# Altium schematic sheet ControlBoard_p2.SchDoc
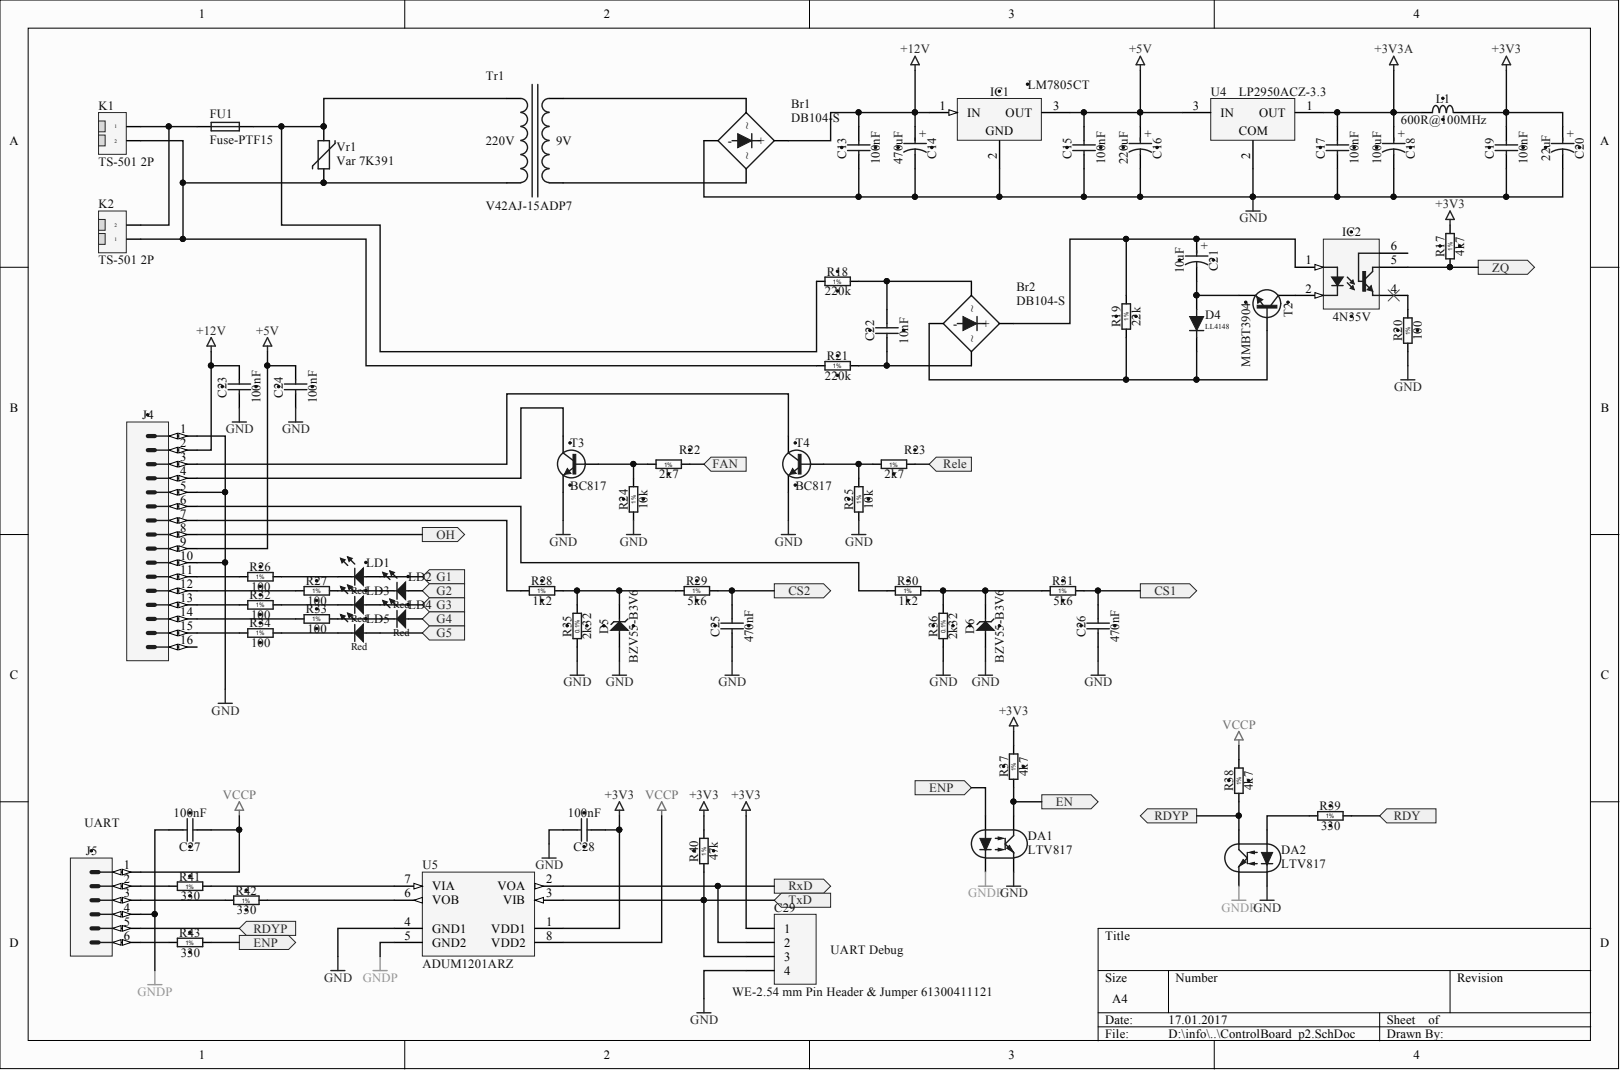
[diagram p0: ControlBoard_p2.SchDoc]

## Parts
Br1  DB104-S  [DB-S]
Br2  DB104-S  [DB-S]
C13  100nF  [CAPC2012X135N]
C14  470uF  [CAPPR500-1050X2200]
C15  100nF  [CAPC2012X135N]
C16  220uF  [CAPPR350-850X1300]
C17  100nF  [CAPC2012X135N]
C18  100uF  [CAPPR350-850X800]
C19  100nF  [CAPC2012X135N]
C20  22uF  [CAPPRB254-600X990]
C21  10uF  [CAPPR200-500X1100]
C22  10nF  [CAPR500-300X720X650]
C23  100nF  [CAPC2012X135N]
C24  100nF  [CAPC2012X135N]
C25  470nF  [CAPC2012X110N]
C26  470nF  [CAPC2012X110N]
C27  100nF  [CAPC2012X135N]
C28  100nF  [CAPC2012X135N]
C29  WE-2.54 mm Pin Header & Jumper 61300411121  [61300411121]
D4  LL4148  [SOD80/MLL34]
D5  BZV55-B3V6  [DIOMELF3515N]
D6  BZV55-B3V6  [DIOMELF3515N]
DA1  LTV817  [DIL4-300a]
DA2  LTV817  [DIL4-300a]
FU1  Fuse-PTF15  [FUSE-MCH]
IC1  LM7805CT  [TO-220-V]
IC2  4N35V  [DIP6-300]
J4  Header 16  [3M_N2516-6002RB]  (value not drawn)
J5  KK®  [MOLEX_22-27-2061]  (value not drawn)
K1  TS-501 2P  [TS-501 2P-2.5]
K2  TS-501 2P  [TS-501 2P-2.5]
L1  600R@100MHz  [INDC2012X110N]
LD1  Super Red  [LED_OSRAM_LSR976]  (value not drawn)
LD2  Super Red  [LED_OSRAM_LSR976]  (value not drawn)
LD3  Super Red  [LED_OSRAM_LSR976]  (value not drawn)
LD4  Super Red  [LED_OSRAM_LSR976]  (value not drawn)
LD5  Super Red  [LED_OSRAM_LSR976]  (value not drawn)
R17  4k7  [RESC2012X60N]
R18  220k  [RES1016-630X250]
R19  22k  [RESC2012X60N]
R20  100  [RESC2012X60N]
R21  220k  [RES1016-630X250]
R22  2k7  [RESC2012X60N]
R23  2k7  [RESC2012X60N]
R24  10k  [RESC2012X60N]
R25  10k  [RESC2012X60N]
R26  100  [RESC2012X60N]
R27  100  [RESC2012X60N]
R28  1k2  [RESC2012X60N]
R29  5k6  [RESC2012X60N]
R30  1k2  [RESC2012X60N]
R31  5k6  [RESC2012X60N]
R32  100  [RESC2012X60N]
R33  100  [RESC2012X60N]
R34  100  [RESC2012X60N]
R35  2k32  [RESC2012X60N]
R36  2k32  [RESC2012X60N]
R37  4k7  [RESC2012X60N]
R38  4k7  [RESC2012X60N]
R39  330  [RESC2012X60N]
R40  47k  [RESC2012X60N]
R41  330  [RESC2012X60N]
R42  330  [RESC2012X60N]
R43  330  [RESC2012X60N]
T2  MMBT3904  [SOT95P230X110-3N]
T3  BC817  [SOT95P230X110-3N]
T4  BC817  [SOT95P230X110-3N]
Tr1  V42AJ-15ADP7  [TR220-6W]
U4  LP2950ACZ-3.3  [LP3]
U5  ADUM1201ARZ  [ADI-R-8_N]
Vr1  Var 7K391  [VAR-7K-200]

## Ports
CS1, CS2, EN, ENP, FAN, G1, G2, G3, G4, G5, OH, RDY, RDYP, RELE, RXD, TXD, ZQ

## Nets
+3V3: C19.2 C20.1 C28.1 C29.1 L1.2 R17.2 R37.2 R40.2 U5.1(VDD1)
+3V3A: C17.2 C18.1 L1.1 U4.1
+5V: C15.2 C16.1 C24.2 IC1.3 J4.9 U4.3
+12V: Br1.1(+) C13.2 C14.1 C23.2 IC1.1 J4.2
GND: Br1.2(-) C13.1 C14.2 C15.1 C16.2 C17.1 C18.2 C19.1 C20.2 C23.1 C24.1 C25.1 C26.1 C28.2 C29.4 D5.2 D6.2 DA1.3 DA2.2 IC1.2 J4.1 J4.5 J4.10 R20.1 R24.1 R25.1 R35.1 R36.1 T3.2 T4.2 U4.2 U5.4(GND1)
GNDP: C27.2 DA1.2 DA2.3 J5.4 U5.5(GND2)
VCCP: C27.1 J5.1 R38.2 U5.8(VDD2)
CS1: C26.2 R31.2 ; port CS1
CS2: C25.2 R29.2 ; port CS2
EN: DA1.4 R37.1 ; port EN
ENP: DA1.1 R43.2 ; port ENP
FAN: R22.2 ; port FAN
G1: LD1.2 ; port G1
G2: LD2.2 ; port G2
G3: LD3.2 ; port G3
G4: LD4.2 ; port G4
G5: LD5.2 ; port G5
OH: J4.8 ; port OH
RDY: R39.2 ; port RDY
RDYP: DA2.4 J5.5 R38.1 ; port RDYP
ZQ: IC2.5 R17.1 ; port ZQ
NetBr1_3: Br1.3(~) Tr1.9
NetBr1_4: Br1.4(~) Tr1.7
NetBr2_1: Br2.1(+) C21.1 IC2.1 R19.2
NetBr2_2: Br2.2(-) D4.2 R19.1 T2.1
NetBr2_3: Br2.3(~) C22.2 R18.2
NetBr2_4: Br2.4(~) C22.1 R21.2
NetC21_2: C21.2 D4.1 T2.2
NetC29_2: C29.2 U5.2(VOA) ; port RXD
NetC29_3: C29.3 R40.1 U5.3(VIB) ; port TXD
NetD5_1: D5.1 R28.2 R29.1 R35.2
NetD6_1: D6.1 R30.2 R31.1 R36.2
NetDA2_1: DA2.1 R39.1
NetFU1_1: FU1.1 R18.1 Tr1.1 Vr1.1
NetFU1_2: FU1.2 K1.1 K2.2
NetIC2_2: IC2.2 T2.3
NetIC2_4: IC2.4 R20.2
NetJ4_3: J4.3 T4.3
NetJ4_4: J4.4 T3.3
NetJ4_6: J4.6 R30.1
NetJ4_7: J4.7 R28.1
NetJ4_11: J4.11 R26.1
NetJ4_12: J4.12 R27.1
NetJ4_13: J4.13 R32.1
NetJ4_14: J4.14 R33.1
NetJ4_15: J4.15 R34.1
NetJ5_2: J5.2 R41.1
NetJ5_3: J5.3 R42.1
NetJ5_6: J5.6 R43.1
NetK1_2: K1.2 K2.1 R21.1 Tr1.5 Vr1.2
NetLD1_1: LD1.1 R26.2
NetLD2_1: LD2.1 R27.2
NetLD3_1: LD3.1 R32.2
NetLD4_1: LD4.1 R33.2
NetLD5_1: LD5.1 R34.2
NetR22_1: R22.1 R24.2 T3.1
NetR23_1: R23.1 R25.2 T4.1
NetR23_2: R23.2 ; port RELE
NetR41_2: R41.2 U5.7(VIA)
NetR42_2: R42.2 U5.6(VOB)

Not connected: IC2 6; J4 16

## Library data of the parts
Br1 Br2 = DB104-S: SMD 1A 400V; Component Kind: Standard; Library Name: Diodes-Bridge.IntLib; Price: 0.23; Signal Integrity: D
C13 C15 C17 C19 C23 C24 C27 C28 = CC0805_100NF_50V_10%_X7R: Non-polarised capacitor; Manufacturer: GENERIC; Manufacturer1 Part Number: B37941K5104K62; Part Description: SMD Multilayer Chip Ceramic Capacitor; Database Table Name: Capacitors SMD; TC: X7R; Tolerance: ±10%; Voltage: 50V; Case: 0805; Component Kind: Standard; Component Type: Standard; CreateDate: 03.12.2007; HelpURL: \\cern.ch\dfs\Applications\Altium\Datasheets\CC0805_X7R_EPCOS.pdf; LatestRevisionDate: 03.12.2007; Library Name: Capacitors.DbLib; Manufacturer1 ComponentHeight: 1.35mm; Manufacturer1 Example: EPCOS; Manufacturer Part Number: CC0805_100NF_50V_10%_X7R; Mounted: Yes; Part Number: CC0805_100NF_50V_10%_X7R; Pin Count: 2; SMD: Yes; Socket: No; Status: Preferred; Table: Capacitors SMD; Value: 100nF; Author: CERN DEM JLC
C14 = CAER_470UF_25V_20%_500-1050X2200_LESR0R062: Polarised capacitor; Manufacturer: VISHAY BCCOMPONENTS; Manufacturer Part Number: MAL213666471E3 Series 136RVI; Part Description: Low ESR 0R062 Aluminum Electrolytic Capacitor; Database Table Name: Capacitors THD; Tolerance: ±20%; Voltage: 25V; Case: LS5 D10.5 x H22; ComponentHeight: 22mm; Component Kind: Standard; Component Type: Standard; CreateDate: 03.07.2008; HelpURL: \\cern.ch\dfs\Applications\Altium\Datasheets\CAER-LESR_VISHAY_136RVI.pdf; LatestRevisionDate: 16.03.2009; Library Name: Capacitors.DbLib; Mounted: Yes; Part Number: CAER_470UF_25V_20%_500-1050X2200_LESR0R062; Pin Count: 2; SMD: No; Socket: No; Status: Preferred; Table: Capacitors THD; Value: 470uF; Author: CERN DEM JLC
C16 = CAER_220UF_25V_20%_RUBYCON_25YXF220M8X11.5: Polarised capacitor; Part Description: Radial Miniature, Aluminum Electrolytic Capacitor, (YXF Series); Database Table Name: Capacitors THD; Tolerance: ±20%; Voltage: 25V; Case: LS3.5 D8.5 x H13; ComponentHeight: 13mm; Component Kind: Standard; Component Type: Standard; CreateDate: 20.08.2009; HelpURL: \\cern.ch\dfs\Applications\Altium\Datasheets\CAER_RUBYCON_YXF.pdf; LatestRevisionDate: 17.09.2009; Library Name: Capacitors.DbLib; Manufacturer: RUBYCON; Manufacturer Part Number: 25YXF220M8X11.5; Mounted: Yes; Part Number: CAER_220UF_25V_20%_RUBYCON_25YXF220M8X11.5; Pin Count: 2; SMD: No; Socket: No; Status: None; Table: Capacitors THD; Value: 220uF; Author: CERN DEM JLC
C18 = CAER_100UF_25V_20%_RUBYCON_25ML100M8X7: Polarised capacitor; Part Description: Aluminum Electrolytic Capacitor, (ML Series); Database Table Name: Capacitors THD; Tolerance: ±20%; Voltage: 25V; Case: LS3.5 D8.5 x H8; ComponentHeight: 8mm; Component Kind: Standard; Component Type: Standard; CreateDate: 17.11.2008; HelpURL: \\cern.ch\dfs\Applications\Altium\Datasheets\CAER_RUBYCON_25ML100M8X7.pdf; LatestRevisionDate: 08.05.2013; Library Name: Capacitors.DbLib; Manufacturer: RUBYCON; Manufacturer Part Number: 25ML100M8X7; Mounted: Yes; Part Number: CAER_100UF_25V_20%_RUBYCON_25ML100M8X7; Pin Count: 2; SMD: No; Socket: No; Status: None; Table: Capacitors THD; Value: 100uF; Author: CERN DEM JMW
C20 = CTEB_22UF_16V_10%_254-600X990: Polarised capacitor; Manufacturer: KEMET; Manufacturer Part Number: T350F226K016AT; Part Description: Solid Tantalum Resin Dipped Capacitor; Database Table Name: Capacitors THD; Tolerance: ±10%; Voltage: 16V; Case: LS2.54 D6 x H9.9; ComponentHeight: 9.9mm; Component Kind: Standard; Component Type: Standard; CreateDate: 16.12.2009; HelpURL: \\cern.ch\dfs\Applications\Altium\Datasheets\CTEB_KEMET_T350.pdf; LatestRevisionDate: 16.12.2009; Library Name: Capacitors.DbLib; Mounted: Yes; Part Number: CTEB_22UF_16V_10%_254-600X990; Pin Count: 2; SMD: No; Socket: No; Status: None; Table: Capacitors THD; Value: 22uF; Author: CERN DEM JLC
C21 = CAER_10UF_63V_20%_PANASONIC_ECA1JM100: Polarised capacitor; Part Description: Aluminum Electrolytic Capacitor (Series: M Type: A); Database Table Name: Capacitors THD; Tolerance: ±20%; Voltage: 63V; Case: LS2 D5 x H11; ComponentHeight: 11mm; Component Kind: Standard; Component Type: Standard; CreateDate: 04.03.2009; HelpURL: \\cern.ch\dfs\Applications\Altium\Datasheets\CAER_PANASONIC_M.pdf; LatestRevisionDate: 04.03.2009; Library Name: Capacitors.DbLib; Manufacturer: PANASONIC; Manufacturer Part Number: ECA1JM100; Mounted: Yes; Part Number: CAER_10UF_63V_20%_PANASONIC_ECA1JM100; Pin Count: 2; SMD: No; Socket: No; Status: None; Table: Capacitors THD; Value: 10uF; Author: CERN DEM JLC
C22 = CPER_10NF_400V_10%_500-300X720X650: Non-polarised capacitor; Manufacturer: EPCOS; Manufacturer Part Number: B32529C6103K000; Part Description: Metallized Polyester Film Capacitor (MKT); Database Table Name: Capacitors THD; Tolerance: ±10%; Voltage: 400VDC/200VAC; Case: LS5 W3 x L7.2 x H6.5; ComponentHeight: 6.5mm; Component Kind: Standard; Component Type: Standard; CreateDate: 23.03.2009; HelpURL: \\cern.ch\dfs\Applications\Altium\Datasheets\CPER_EPCOS_B32529.pdf; LatestRevisionDate: 23.03.2009; Library Name: Capacitors.DbLib; Mounted: Yes; Part Number: CPER_10NF_400V_10%_500-300X720X650; Pin Count: 2; SMD: No; Socket: No; Status: None; Table: Capacitors THD; Value: 10nF; Author: CERN DEM JLC
C25 C26 = CC0805_470NF_50V_10%_X7R: Non-polarised capacitor; Manufacturer: GENERIC; Manufacturer1 Part Number: C0805C474K5RACTU; Part Description: SMD Multilayer Chip Ceramic Capacitor; Database Table Name: Capacitors SMD; TC: X7R; Tolerance: ±10%; Voltage: 50V; Case: 0805; Component Kind: Standard; Component Type: Standard; CreateDate: 04.12.2013; HelpURL: \\cern.ch\dfs\Applications\Altium\Datasheets\CC0805_X7R_KEMET.pdf; LatestRevisionDate: 04.12.2013; Library Name: Capacitors.DbLib; Manufacturer1 ComponentHeight: 1.1mm; Manufacturer1 Example: KEMET; Manufacturer Part Number: CC0805_470NF_50V_10%_X7R; Mounted: Yes; Part Number: CC0805_470NF_50V_10%_X7R; Pin Count: 2; SMD: Yes; Socket: No; Status: Preferred; Table: Capacitors SMD; Value: 470nF; Author: CERN DEM JLC
C29 = WE-2.54 mm Pin Header & Jumper 61300411121: WR-PHD 2.54mm Pin Header; Manufacturer: WЁ№rth Elektronik eiSos GmbH; ComponentLink1 Description: Manufacturer; ComponentLink2 Description: Datasheet; NoPins: 04; Component Kind: Standard; ComponentLink1URL: http://www.we-online.com; ComponentLink2URL: http://katalog.we-online.de/em/datasheet/6130xx11121.pdf; Created Date: 22 March 2013; Library Name: WE_WR-PHD_Pin Header_2.54mm_rev15c.IntLib; partNo: 61300411121; Created by: Karrer Zheng
D4 = LL4148: 75V 0.3A SOD80/MLL34; Component Kind: Standard; Library Name: Diodes.IntLib; Price: 0.006; Signal Integrity: D; Simulation: 1N4148
D5 D6 = BZV55-B3V6: Zener Diode; Manufacturer: NXP SEMICONDUCTORS; Part Description: Voltage Regulator Diode; Database Table Name: Diodes; Power: 500mW; Voltage: 3V6; Case: SOD-80C; ComponentHeight: 1.6mm; Component Kind: Standard; Component Type: Standard; CreateDate: 06.05.2008; Device: BZV55-B3V6; HelpURL: \\cern.ch\dfs\Applications\Altium\Datasheets\BZV55.pdf; LatestRevisionDate: 06.05.2008; Library Name: ICs And Semiconductors.DbLib; Manufacturer Part Number: BZV55-B3V6; Mounted: Yes; PackageDescription: SOD80C, MELF Diode, 2-Leads, Body 3.5x1.5mm, IPC Medium Density; Part Number: BZV55-B3V6; Pin Count: 2; SMD: Yes; Socket: No; Table: Diodes; Author: CERN DEM JLC
FU1 = Fuse-PTF15: 5mm 6.3A; Component Kind: Standard; Library Name: Devise.IntLib; Price: 1
IC1 = LM7805CT_v: 3-Terminal Regulator 1=IN 2=GND 3=OUT; Manufacturer: FAIRCHILD SEMICONDUCTOR; Part Description: 3-Terminal 5V 1A Positive Voltage Regulator; Database Table Name: Regulators; Case: TO-220; ComponentHeight: 18.95mm; Component Kind: Standard; Component Type: Standard; CreateDate: 18.03.2008; Device: LM7805CT; HelpURL: \\cern.ch\dfs\Applications\Altium\Datasheets\LM7805CT.pdf; LatestRevisionDate: 18.03.2008; Library Name: ICs And Semiconductors.DbLib; Manufacturer Part Number: LM7805CT; Mounted: Yes; PackageDescription: TO-220 3-Terminal + Tab - Horizontal Mount; Part Number: LM7805CT_v; Pin Count: 3; SMD: No; Socket: No; Table: Regulators; Author: CERN DEM JLC
IC2 = 4N25V: Single Optocoupler with Base; Manufacturer: VISHAY SEMICONDUCTORS; Part Description: Optocoupler, Phototransistor Output, With Base Connection; Database Table Name: Optocouplers; Case: DIP6-300; ComponentHeight: 4.6mm; Component Kind: Standard; Component Type: Standard; CreateDate: 25.10.2012; Device: 4N35V; HelpURL: \\cern.ch\dfs\Applications\Altium\Datasheets\4N25V.pdf; LatestRevisionDate: 25.10.2012; Library Name: ICs And Semiconductors.DbLib; Manufacturer Part Number: 4N25V; Mounted: Yes; PackageDescription: DIP; 6 Leads; Row Spacing 7.62 mm; Pitch 2.54 mm; Part Number: 4N35V; Pin Count: 6; SMD: No; Socket: Yes; Table: Optocouplers; Author: CERN DEM JLC
J4 = 3M_N2516-6002RB: Connector 16 Male; Part Description: 16 Contacts - Straight .100'' x .100'' Low Profile Four-Wall Header, 2500 Series; Database Table Name: 3M; ComponentHeight: 10.03mm; Component Kind: Standard; Component Type: Standard; CreateDate: 11.02.2009; Family: Header 16; HelpURL: \\cern.ch\dfs\Applications\Altium\Datasheets\3M_N2516-6002RB.pdf; LatestRevisionDate: 11.08.2009; Library Name: Connectors.DbLib; Manufacturer: 3M; Manufacturer Part Number: N2516-6002RB; Mounted: Yes; Part Number: 3M_N2516-6002RB; Pin Count: 16; SMD: No; Socket: No; Status: None; Table: 3M; Author: CERN DEM JLC
J5 = MOLEX_22-27-2061: Connector 6 Male; Part Description: 2.54mm (.100") Pitch KK® Wire-to-Board Header, Vertical, with Friction Lock, 6 Circuits, Tin (Sn) Plating; Database Table Name: MOLEX; ComponentHeight: 11.7mm; Component Kind: Standard; Component Type: Standard; CreateDate: 30.11.2011; Family: KK®; HelpURL: \\cern.ch\dfs\Applications\Altium\Datasheets\MOLEX_22-27-2061.pdf; LatestRevisionDate: 30.11.2011; Library Name: Connectors.DbLib; Manufacturer: MOLEX; Manufacturer Part Number: 22-27-2061; Mounted: Yes; Part Number: MOLEX_22-27-2061; Pin Count: 6; SMD: No; Socket: No; Status: None; Table: MOLEX; Author: CERN DEM JMW
K1 K2 = TS-501 2P: 10A/300V AC 5mm; Component Kind: Standard; Library Name: Connektor.IntLib; Price: 0.27
L1 = IND0805_WURTH_742792040: Inductor; Manufacturer: WURTH ELEKTRONIK; Part Description: SMD EMI Suppression Chip Ferrite Bead (WE-CBF Serie); Database Table Name: Inductors SMD; Power: 2A; Resistance: 0.150R; Tolerance: ±25%; Case: 0805; ComponentHeight: 1.1mm; Component Kind: Standard; Component Type: Standard; CreateDate: 10.05.2010; HelpURL: \\cern.ch\dfs\Applications\Altium\Datasheets\IND0805_WURTH_742792040.pdf; LatestRevisionDate: 10.05.2010; Library Name: Inductors & Transformers.DbLib; Manufacturer Part Number: 742792040; Mounted: Yes; Part Number: IND0805_WURTH_742792040; Pin Count: 2; SMD: Yes; Socket: No; Status: None; Table: Inductors SMD; Value: 600R@100MHz; Author: CERN DEM JLC
LD1-LD5 = LED_OSRAM_LSR976: LED Red 1C 2A; Manufacturer: OSRAM; Manufacturer Part Number: LSR976; Part Description: Surface Mount Super Red LED (0805 Case); Database Table Name: LEDs & Displays; Case: 0805; Color: Super Red; ComponentHeight: 0.9mm; Component Kind: Standard; Component Type: Standard; CreateDate: 18.05.2010; HelpURL: \\cern.ch\dfs\Applications\Altium\Datasheets\LED_OSRAM_LSR976.pdf; LatestRevisionDate: 18.05.2010; Library Name: ICs And Semiconductors.DbLib; Mounted: Yes; PackageDescription: Super Red Chip LED, 2-Leads, Body 2 x1.25mm, IPC Medium Density; Part Number: LED_OSRAM_LSR976; Pin Count: 2; SMD: Yes; Socket: No; Table: LEDs & Displays; Author: CERN DEM JLC
R17 R37 R38 = R0805_4K7_1%_0.125W_100PPM: Resistor - 1%; Manufacturer: GENERIC; Manufacturer1 Part Number: NRC10F4701TRF; Part Description: General Purpose Thick Film Chip Resistor; Database Table Name: Resistors SMD; Power: 0.125W; TC: ±100ppm/°C; Case: 0805; Component Kind: Standard; Component Type: Standard; CreateDate: 03.12.2007; HelpURL: \\cern.ch\dfs\Applications\Altium\Datasheets\R0805_NIC_NRC.pdf; LatestRevisionDate: 13.03.2008; Library Name: Resistors.DbLib; Manufacturer1 ComponentHeight: 0.6mm; Manufacturer1 Example: NIC COMPONENT; Manufacturer Part Number: R0805_4K7_1%_0.125W_100PPM; Mounted: Yes; Part Number: R0805_4K7_1%_0.125W_100PPM; Pin Count: 2; SMD: Yes; Socket: No; Status: Preferred; Table: Resistors SMD; Tolerance: ±1%; Value: 4k7; Author: CERN DEM JLC
R18 R21 = RMF_220K_1%_0.6W_50PPM_1016-630X250: Resistor - 1%; Manufacturer: GENERIC; Manufacturer1 Part Number: MBB02070C2203FCT00; Part Description: Leaded Metal Film Resistor; Database Table Name: Resistors THD; Power: 0.6W; TC: ±50ppm/°C; Case: LS10.16 L6.3 x D2.5; ComponentHeight: 2.5mm; Component Kind: Standard; Component Type: Standard; CreateDate: 04.12.2007; HelpURL: \\cern.ch\dfs\Applications\Altium\Datasheets\RMF_VISHAY_MBB0207.pdf; LatestRevisionDate: 07.04.2008; Library Name: Resistors.DbLib; Manufacturer1 ComponentHeight: 2.5mm; Manufacturer1 Example: VISHAY BEYSCHLAG; Manufacturer Part Number: RMF_220K_1%_0.6W_50PPM_1016-630X250; Mounted: Yes; Part Number: RMF_220K_1%_0.6W_50PPM_1016-630X250; Pin Count: 2; SMD: No; Socket: No; Status: Preferred; Table: Resistors THD; Tolerance: ±1%; Value: 220k; Author: CERN DEM JLC
R19 = R0805_22K_1%_0.125W_100PPM: Resistor - 1%; Manufacturer: GENERIC; Manufacturer1 Part Number: NRC10F2202TRF; Part Description: General Purpose Thick Film Chip Resistor; Database Table Name: Resistors SMD; Power: 0.125W; TC: ±100ppm/°C; Case: 0805; Component Kind: Standard; Component Type: Standard; CreateDate: 03.12.2007; HelpURL: \\cern.ch\dfs\Applications\Altium\Datasheets\R0805_NIC_NRC.pdf; LatestRevisionDate: 13.03.2008; Library Name: Resistors.DbLib; Manufacturer1 ComponentHeight: 0.6mm; Manufacturer1 Example: NIC COMPONENT; Manufacturer Part Number: R0805_22K_1%_0.125W_100PPM; Mounted: Yes; Part Number: R0805_22K_1%_0.125W_100PPM; Pin Count: 2; SMD: Yes; Socket: No; Status: Preferred; Table: Resistors SMD; Tolerance: ±1%; Value: 22k; Author: CERN DEM JLC
R20 R26 R27 R32-R34 = R0805_100R_1%_0.125W_100PPM: Resistor - 1%; Manufacturer: GENERIC; Manufacturer1 Part Number: NRC10F4700TRF; Part Description: General Purpose Thick Film Chip Resistor; Database Table Name: Resistors SMD; Power: 0.125W; TC: ±100ppm/°C; Case: 0805; Component Kind: Standard; Component Type: Standard; CreateDate: 03.12.2007; HelpURL: \\cern.ch\dfs\Applications\Altium\Datasheets\R0805_NIC_NRC.pdf; LatestRevisionDate: 13.03.2008; Library Name: Resistors.DbLib; Manufacturer1 ComponentHeight: 0.6mm; Manufacturer1 Example: NIC COMPONENT; Manufacturer Part Number: R0805_100R_1%_0.125W_100PPM; Mounted: Yes; Part Number: R0805_100R_1%_0.125W_100PPM; Pin Count: 2; SMD: Yes; Socket: No; Status: Preferred; Table: Resistors SMD; Tolerance: ±1%; Value: 100; Author: CERN DEM JLC
R22 R23 = R0805_2K7_1%_0.125W_100PPM: Resistor - 1%; Manufacturer: GENERIC; Manufacturer1 Part Number: NRC10F2701TRF; Part Description: General Purpose Thick Film Chip Resistor; Database Table Name: Resistors SMD; Power: 0.125W; TC: ±100ppm/°C; Case: 0805; Component Kind: Standard; Component Type: Standard; CreateDate: 08.06.2010; HelpURL: \\cern.ch\dfs\Applications\Altium\Datasheets\R0805_NIC_NRC.pdf; LatestRevisionDate: 08.06.2010; Library Name: Resistors.DbLib; Manufacturer1 ComponentHeight: 0.6mm; Manufacturer1 Example: NIC COMPONENT; Manufacturer Part Number: R0805_2K7_1%_0.125W_100PPM; Mounted: Yes; Part Number: R0805_2K7_1%_0.125W_100PPM; Pin Count: 2; SMD: Yes; Socket: No; Status: Preferred; Table: Resistors SMD; Tolerance: ±1%; Value: 2k7; Author: CERN DEM JLC
R24 R25 = R0805_10K_1%_0.125W_100PPM: Resistor - 1%; Manufacturer: GENERIC; Manufacturer1 Part Number: NRC10F1002TRF; Part Description: General Purpose Thick Film Chip Resistor; Database Table Name: Resistors SMD; Power: 0.125W; TC: ±100ppm/°C; Case: 0805; Component Kind: Standard; Component Type: Standard; CreateDate: 03.12.2007; HelpURL: \\cern.ch\dfs\Applications\Altium\Datasheets\R0805_NIC_NRC.pdf; LatestRevisionDate: 13.03.2008; Library Name: Resistors.DbLib; Manufacturer1 ComponentHeight: 0.6mm; Manufacturer1 Example: NIC COMPONENT; Manufacturer Part Number: R0805_10K_1%_0.125W_100PPM; Mounted: Yes; Part Number: R0805_10K_1%_0.125W_100PPM; Pin Count: 2; SMD: Yes; Socket: No; Status: Preferred; Table: Resistors SMD; Tolerance: ±1%; Value: 10k; Author: CERN DEM JLC
R28 R30 = R0805_1K2_1%_0.125W_100PPM: Resistor - 1%; Manufacturer: GENERIC; Manufacturer1 Part Number: NRC10F1201TRF; Part Description: General Purpose Thick Film Chip Resistor; Database Table Name: Resistors SMD; Power: 0.125W; TC: ±100ppm/°C; Case: 0805; Component Kind: Standard; Component Type: Standard; CreateDate: 03.12.2007; HelpURL: \\cern.ch\dfs\Applications\Altium\Datasheets\R0805_NIC_NRC.pdf; LatestRevisionDate: 13.03.2008; Library Name: Resistors.DbLib; Manufacturer1 ComponentHeight: 0.6mm; Manufacturer1 Example: NIC COMPONENT; Manufacturer Part Number: R0805_1K2_1%_0.125W_100PPM; Mounted: Yes; Part Number: R0805_1K2_1%_0.125W_100PPM; Pin Count: 2; SMD: Yes; Socket: No; Status: Preferred; Table: Resistors SMD; Tolerance: ±1%; Value: 1k2; Author: CERN DEM JLC
R29 R31 = R0805_5K6_1%_0.125W_100PPM: Resistor - 1%; Manufacturer: GENERIC; Manufacturer1 Part Number: NRC10F5601TRF; Part Description: General Purpose Thick Film Chip Resistor; Database Table Name: Resistors SMD; Power: 0.125W; TC: ±100ppm/°C; Case: 0805; Component Kind: Standard; Component Type: Standard; CreateDate: 03.12.2007; HelpURL: \\cern.ch\dfs\Applications\Altium\Datasheets\R0805_NIC_NRC.pdf; LatestRevisionDate: 13.03.2008; Library Name: Resistors.DbLib; Manufacturer1 ComponentHeight: 0.6mm; Manufacturer1 Example: NIC COMPONENT; Manufacturer Part Number: R0805_5K6_1%_0.125W_100PPM; Mounted: Yes; Part Number: R0805_5K6_1%_0.125W_100PPM; Pin Count: 2; SMD: Yes; Socket: No; Status: Preferred; Table: Resistors SMD; Tolerance: ±1%; Value: 5k6; Author: CERN DEM JLC
R35 R36 = R0805_2K32_0.1%_0.1W_10PPM: Resistor - 0.1%; Manufacturer: GENERIC; Manufacturer1 Part Number: RN73C2A2K32BTG; Part Description: Thin Film Chip Resistor; Database Table Name: Resistors SMD; Power: 0.1W; TC: ±10ppm; Case: 0805; Component Kind: Standard; Component Type: Standard; CreateDate: 03.12.2007; HelpURL: \\cern.ch\dfs\Applications\Altium\Datasheets\R0805_Tyco_RN73.pdf; LatestRevisionDate: 13.03.2008; Library Name: Resistors.DbLib; Manufacturer1 ComponentHeight: 0.6mm; Manufacturer1 Example: TYCO HOLSWORTHY; Manufacturer Part Number: R0805_2K32_0.1%_0.1W_10PPM; Mounted: Yes; Part Number: R0805_2K32_0.1%_0.1W_10PPM; Pin Count: 2; SMD: Yes; Socket: No; Status: Not recommended; Table: Resistors SMD; Tolerance: ±0.1%; Value: 2k32; Author: CERN DEM JLC
R39 R41-R43 = R0805_330R_1%_0.125W_100PPM: Resistor - 1%; Manufacturer: GENERIC; Manufacturer1 Part Number: NRC10F3300TRF; Part Description: General Purpose Thick Film Chip Resistor; Database Table Name: Resistors SMD; Power: 0.125W; TC: ±100ppm/°C; Case: 0805; Component Kind: Standard; Component Type: Standard; CreateDate: 03.12.2007; HelpURL: \\cern.ch\dfs\Applications\Altium\Datasheets\R0805_NIC_NRC.pdf; LatestRevisionDate: 13.03.2008; Library Name: Resistors.DbLib; Manufacturer1 ComponentHeight: 0.6mm; Manufacturer1 Example: NIC COMPONENT; Manufacturer Part Number: R0805_330R_1%_0.125W_100PPM; Mounted: Yes; Part Number: R0805_330R_1%_0.125W_100PPM; Pin Count: 2; SMD: Yes; Socket: No; Status: Preferred; Table: Resistors SMD; Tolerance: ±1%; Value: 330; Author: CERN DEM JLC
R40 = R0805_47K_1%_0.125W_100PPM: Resistor - 1%; Manufacturer: GENERIC; Manufacturer1 Part Number: NRC10F4702TRF; Part Description: General Purpose Thick Film Chip Resistor; Database Table Name: Resistors SMD; Power: 0.125W; TC: ±100ppm/°C; Case: 0805; Component Kind: Standard; Component Type: Standard; CreateDate: 03.12.2007; HelpURL: \\cern.ch\dfs\Applications\Altium\Datasheets\R0805_NIC_NRC.pdf; LatestRevisionDate: 13.03.2008; Library Name: Resistors.DbLib; Manufacturer1 ComponentHeight: 0.6mm; Manufacturer1 Example: NIC COMPONENT; Manufacturer Part Number: R0805_47K_1%_0.125W_100PPM; Mounted: Yes; Part Number: R0805_47K_1%_0.125W_100PPM; Pin Count: 2; SMD: Yes; Socket: No; Status: Preferred; Table: Resistors SMD; Tolerance: ±1%; Value: 47k; Author: CERN DEM JLC
T2 = MMBT3904: NPN Transistor; Manufacturer: NXP SEMICONDUCTORS; Part Description: 40V 200mA NPN Switching Transistor; Database Table Name: Transistors; Case: SOT23-3; ComponentHeight: 1.1mm; Component Kind: Standard; Component Type: Standard; CreateDate: 10.02.2010; Device: MMBT3904; HelpURL: \\cern.ch\dfs\Applications\Altium\Datasheets\MMBT3904.pdf; LatestRevisionDate: 10.02.2010; Library Name: ICs And Semiconductors.DbLib; Manufacturer Part Number: MMBT3904; Mounted: Yes; PackageDescription: SOT23, 3 pin, Pitch 0.95mm - 1.3mm W X 2.9mm L X 1.1mm H, IPC Medium Density; Part Number: MMBT3904; Pin Count: 3; SMD: Yes; Socket: No; Table: Transistors; Author: CERN DEM JLC
T3 T4 = BC817: NPN Transistor; Manufacturer: NXP SEMICONDUCTORS; Part Description: 45V 500mA NPN General-Purpose Transistor; Database Table Name: Transistors; Case: SOT23-3; ComponentHeight: 1.1mm; Component Kind: Standard; Component Type: Standard; CreateDate: 12.05.2011; Device: BC817; HelpURL: \\cern.ch\dfs\Applications\Altium\Datasheets\BC817.pdf; LatestRevisionDate: 12.05.2011; Library Name: ICs And Semiconductors.DbLib; Manufacturer Part Number: BC817; Mounted: Yes; PackageDescription: SOT23, 3 pin, Pitch 0.95mm - 1.3mm W X 2.9mm L X 1.1mm H, IPC Medium Density; Part Number: BC817; Pin Count: 3; SMD: Yes; Socket: No; Table: Transistors; Author: CERN DEM JLC
U4 = UA78L02ACLP: Fixed Regulator with 4.75 to 20 V Input and 2.6 V Output, 0 to 125 degC, 3-Pin TO-92 (LP), Pb-Free (RoHS); Manufacturer: Texas Instruments; Iout(Max)(A): 0.1; Iq(Typ)(mA): 6; Vin(Max)(V): 20; Vin(Min)(V): 4.75; Vout(Max)(V): 2.6; Code_JEDEC: TO-226-AA; Component Kind: Standard; ComponentLink1Description: Datasheet; ComponentLink1URL: http://www.ti.com/general/docs/lit/getliterature.tsp?genericPartNumber=UA78L02A&fileType=pdf; ComponentLink2Description: Package Specification; ComponentLink2URL: http://www.ti.com/litv/pdf/msot002a; ComponentLink3Description: URL Link1; ComponentLink3URL: Samples URL:http://www.ti.com/product/ua78l02a#samples; DatasheetVersion: SLVS010S; Eco-Plan: Pb-Free (RoHS); Library Name: TI Power Management Standard Voltage Regulator.IntLib; Mounting Technology: Through Hole; PackageDescription: Plastic Cylindrical Package, Formed Lead Option (TO-92); PackageReference: LP3; PackageVersion: revC, Oct-2001; PartNumber: UA78L02ACLP; Supplier 1: Digi-Key; Supplier 2: Newark; Supplier 3: Mouser; Supplier Part Number 1: 296-11117-5-ND; Supplier Part Number 2: 14P7576; Supplier Part Number 3: 595-UA78L02ACLP; Vout(Min)(V): 2.6
U5 = ADUM1201ARZ: Dual Channel Digital Isolator, 2.5 KV rms Insulation Rating, 1 Mbps Data Rate, 150 ns Delay, Industrial, 8-pin SOIC (R-8), Tube; Manufacturer: Analog Devices; Insulation Rating (kVrms): 2.5; Max Data Rate: 1Mbps; Code_JEDEC: MS-012-AA; Component Kind: Standard; ComponentLink1Description: Manufacturer URL; ComponentLink1URL: http://www.analog.com/en/index.html; ComponentLink2Description: Datasheet; ComponentLink2URL: http://www.analog.com/static/imported-files/data_sheets/ADuM1200_1201.pdf; ComponentLink3Description: Package Specification; ComponentLink3URL: http://www.analog.com/static/imported-files/packages/PKG_PDF/SOIC_Narrow%20(R)/R_8.pdf; ComponentLink4Description: URL Link1; ComponentLink4URL: Product URL:http://www.analog.com/en/interface-isolation/digital-isolators/adum1201/products/product.html; ComponentLink5Description: URL Link2; ComponentLink5URL: Reference Circuit:http://www.analog.com/en/interface-isolation/digital-isolators/adum1201/products/product.html#product-recommendations; DatasheetVersion: Rev. I; Max Operating Temp (degreesC): 125; Mounting Technology: Surface Mount; PackageDescription: 8-Pin Standard Small Outline Package, Body 4.9 x 3.9 mm, Pitch 1.27 mm; PackageReference: R-8; PackageVersion: 060506-A, 06/2006; PartNumber: ADUM1201ARZ; Supplier 1: Digi-Key; Supplier 2: Farnell; Supplier 3: Mouser; Supplier Part Number 1: ADUM1201ARZ-ND; Supplier Part Number 2: 1078200; Supplier Part Number 3: 584-ADUM1201ARZ; Library Name: Analog Devices Interface and Isolation Digital Isolators.IntLib
Vr1 = Var 7K391: Варистор 7mm; Component Kind: Standard; Library Name: Devise.IntLib; Price: 0.1
DA1 DA2 = LTV817: Component Kind: Standard; Price: 0.28; Simulation: PC817; Library Name: Opto Coupler.IntLib
Tr1 = V42AJ-15ADP7: Component Kind: Standard; Description: V42AJ-15ADP7; Library Name: PowerLib.SchLib
